# Altium schematic sheet sdd_radio_circuits.SchDoc(sdd_radio_circuits)
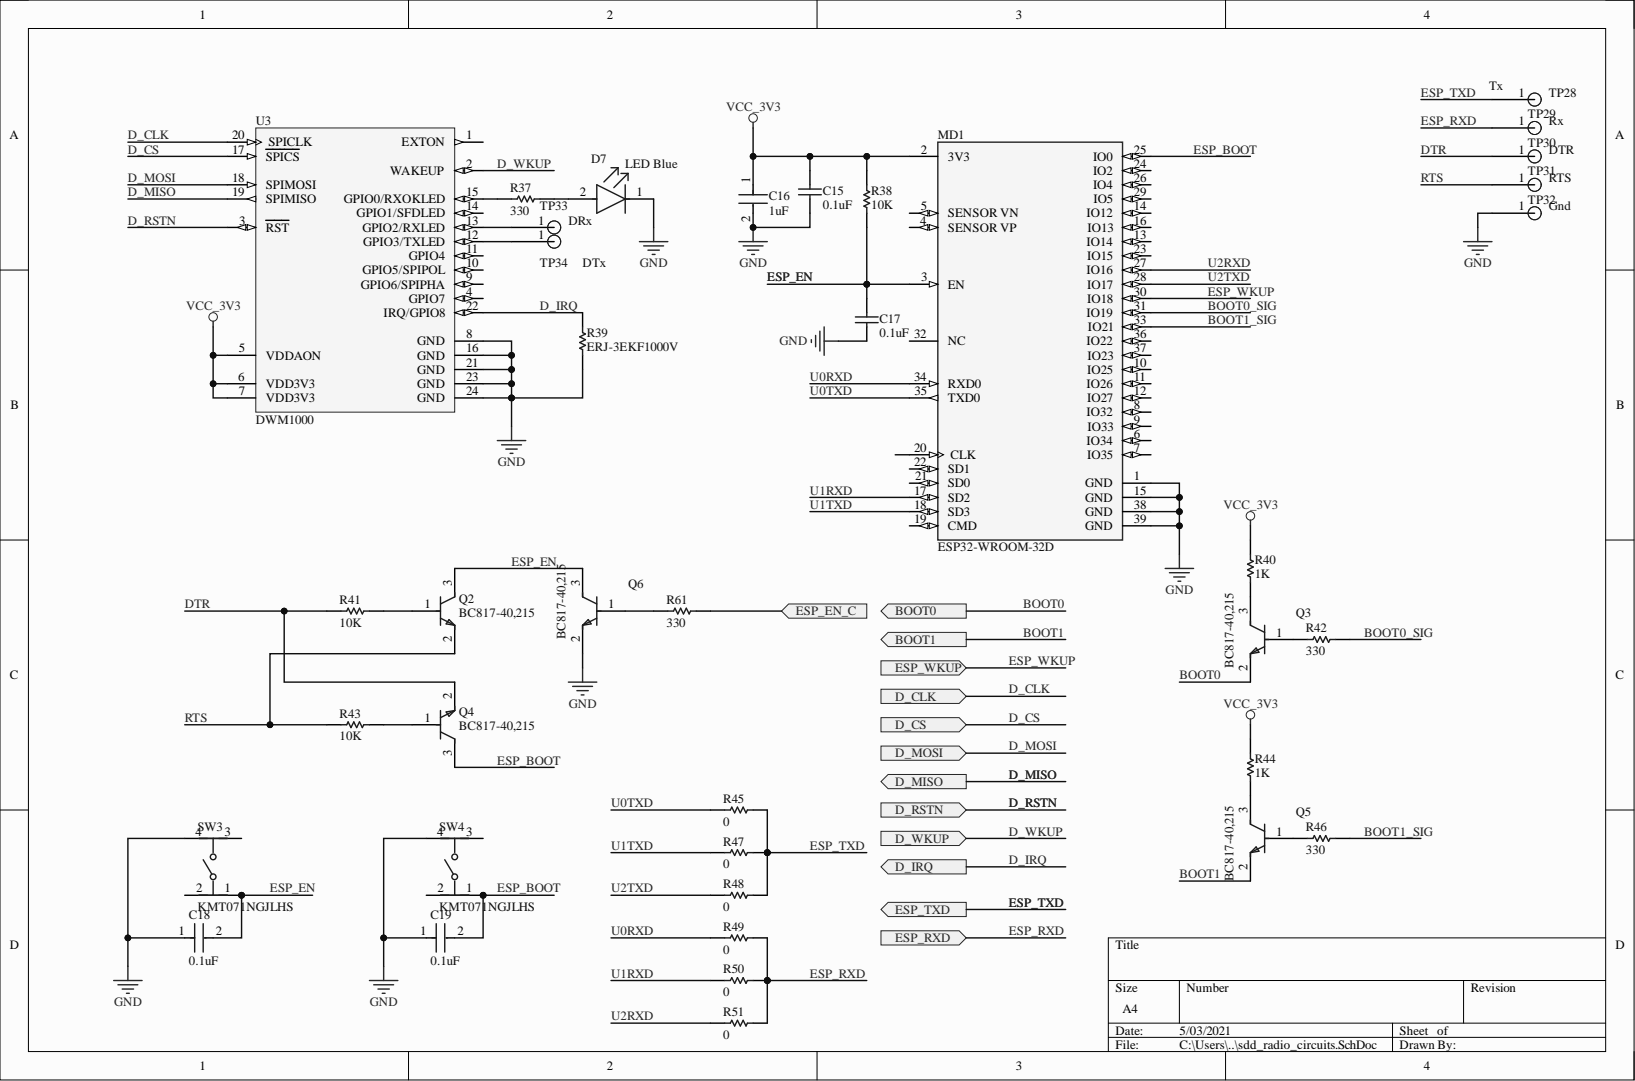
[diagram p0: sdd_radio_circuits.SchDoc]

## Parts
C15  0.1uF  [CAPC1608X90X35ML15T15]
C16  1uF  [FP-CL10B105KO8NNNC-MFG]
C17  0.1uF  [CAPC1608X90X35ML15T15]
C18  0.1uF  [FP-GRM022-0_02-IPC_A]
C19  0.1uF  [FP-GRM022-0_02-IPC_A]
D7  LED Blue  Color: Blue  [FP-QBLP595-IB-MFG]
MD1  ESP32-WROOM-32D  [FP-ESP32-WROOM-32D-MFG]
Q2  BC817-40,215  [FP-SOT23-MFG]
Q3  BC817-40,215  [FP-SOT23-MFG]
Q4  BC817-40,215  [FP-SOT23-MFG]
Q5  BC817-40,215  [FP-SOT23-MFG]
Q6  BC817-40,215  [FP-SOT23-MFG]
R37  330  [RESC1005X40X25LL05T10]
R38  10K  [RESC1608X55X30ML20T20]
R39  ERJ-3EKF1000V  [RESC1608X55X30LL15T15]
R40  1K  [RESC1005X40X25LL05T10]
R41  10K  [RESC1608X55X30ML20T20]
R42  330  [RESC1005X40X25LL05T10]
R43  10K  [RESC1608X55X30ML20T20]
R44  1K  [RESC1005X40X25LL05T10]
R45  0  [RESC1005X40X25NL05T10]
R46  330  [RESC1005X40X25LL05T10]
R47  0  [RESC1005X40X25NL05T10]
R48  0  [RESC1005X40X25NL05T10]
R49  0  [RESC1005X40X25NL05T10]
R50  0  [RESC1005X40X25NL05T10]
R51  0  [RESC1005X40X25NL05T10]
R61  330  [RESC1005X40X25LL05T10]
SW3  KMT071NGJLHS  [FP-KMT071NGJLHS-MFG]
SW4  KMT071NGJLHS  [FP-KMT071NGJLHS-MFG]
TP28  Tx  [Testpoint1]  (no BOM)
TP29  Rx  [Testpoint2]  (no BOM)
TP30  DTR  [Testpoint2]  (no BOM)
TP31  RTS  [Testpoint2]  (no BOM)
TP32  Gnd  [Testpoint2]  (no BOM)
TP33  DRx  [Testpoint2]  (no BOM)
TP34  DTx  [Testpoint2]  (no BOM)
U3  DWM1000  [DECW-DWM1000-24_V]

## Ports
BOOT0, BOOT1, D_CLK, D_CS, D_IRQ, D_MISO, D_MOSI, D_RSTN, D_WKUP, ESP_EN_C, ESP_RXD, ESP_TXD, ESP_WKUP

## Nets
GND: C15.2 C16.2 C17.1 C18.1 C19.1 D7.1 MD1.1(GND) MD1.15(GND) MD1.38(GND) MD1.39(GND) Q6.2 R39.1 SW3.4 SW4.4 TP32.1 U3.8(GND) U3.16(GND) U3.21(GND) U3.23(GND) U3.24(GND)
VCC_3V3: C15.1 C16.1 MD1.2(3V3) R38.2 R40.1 R44.1 U3.5(VDDAON) U3.6(VDD3V3) U3.7(VDD3V3)
BOOT0: Q3.2 ; port BOOT0
BOOT0_SIG: MD1.31(IO19) R42.2
BOOT1: Q5.2 ; port BOOT1
BOOT1_SIG: MD1.33(IO21) R46.2
D_CLK: U3.20(SPICLK) ; port D_CLK
D_CS: U3.17(SPICS) ; port D_CS
D_IRQ: R39.2 U3.22(IRQ/GPIO8) ; port D_IRQ
D_MISO: U3.19(SPIMISO) ; port D_MISO
D_MOSI: U3.18(SPIMOSI) ; port D_MOSI
D_RSTN: U3.3(RST) ; port D_RSTN
D_WKUP: U3.2(WAKEUP) ; port D_WKUP
DTR: Q4.2 R41.1 TP30.1
ESP_BOOT: C19.2 MD1.25(IO0) Q4.3 SW4.1
ESP_EN: C17.2 C18.2 MD1.3(EN) Q2.3 Q6.3 R38.1 SW3.1
ESP_EN_C: R61.2 ; port ESP_EN_C
ESP_RXD: R49.2 R50.2 R51.2 TP29.1 ; port ESP_RXD
ESP_TXD: R45.2 R47.2 R48.2 TP28.1 ; port ESP_TXD
ESP_WKUP: MD1.30(IO18) ; port ESP_WKUP
RTS: Q2.2 R43.1 TP31.1
U0RXD: MD1.34(RXD0) R49.1
U0TXD: MD1.35(TXD0) R45.1
U1RXD: MD1.17(SD2) R50.1
U1TXD: MD1.18(SD3) R47.1
U2RXD: MD1.27(IO16) R51.1
U2TXD: MD1.28(IO17) R48.1
NetD7_2: D7.2 R37.2
NetQ2_1: Q2.1 R41.2
NetQ3_1: Q3.1 R42.1
NetQ3_3: Q3.3 R40.2
NetQ4_1: Q4.1 R43.2
NetQ5_1: Q5.1 R46.1
NetQ5_3: Q5.3 R44.2
NetQ6_1: Q6.1 R61.1
NetR37_1: R37.1 U3.15(GPIO0/RXOKLED)
NetTP33_1: TP33.1 U3.13(GPIO2/RXLED)
NetTP34_1: TP34.1 U3.12(GPIO3/TXLED)

Not connected: MD1 4(SENSOR_VP) 5(SENSOR_VN) 6(IO34) 7(IO35) 8(IO32) 9(IO33) 10(IO25) 11(IO26) 12(IO27) 13(IO14) 14(IO12) 16(IO13) 19(CMD) 20(CLK) 21(SD0) 22(SD1) 23(IO15) 24(IO2) 26(IO4) 29(IO5) 32(NC) 36(IO22) 37(IO23); SW3 2 3; SW4 2 3; U3 1(EXTON) 4(GPIO7) 9(GPIO6/SPIPHA) 10(GPIO5/SPIPOL) 11(GPIO4) 14(GPIO1/SFDLED)

## Library data of the parts
C15 C17 = CMP-2006-04492-1: Manufacturer: AVX Interconnect / Elco; Part Number: 0603YC104K4T4A; Component Kind: Standard; Library Name: Altium Content Vault
C16 = CMP-2000-06093-2: CAP CER 1UF 16V X7R 0603; Manufacturer: Samsung Electro-Mechanics America, Inc; Manufacturer Part Number: CL10B105KO8NNNC; Material: Ceramic; Temperature Coefficient: 15%; Tolerance: 10%; Automotive: No; Capacitance: 1uF; Category: Cap; Component Kind: Standard; ComponentLink2Description: Datasheet; ComponentLink2URL: http://www.samsungsem.com/global/front/downloadcms.do?path=%2F%2Fglobal%2F%2Fsupport%2F%2Fproduct-search%2F%2Fmlcc%2F%2F__icsFiles%2F%2Fafieldfile%2F%2F2019%2F%2F06%2F%2F18&fileName=CL10B105KO8NNNC-19.pdf; Device Class L1: Passive Components; Device Class L2: Capacitors; Device Class L3: Ceramic Capacitors; Digikey Description: CAP CER 1UF 16V X7R 0603; Height: 0.9mm; Ipc Land Pattern Name: CAPC16080X80; Lead Free: Yes; Library Name: Altium Content Vault; Octopart: https://octopart.com/cl10b105ko8nnnc-samsung-19018973; Package: CL10B105KO8NNNC; RoHS: TRUE; Temperature: -55°C to +125°C; Temperature Characteristic: X7R; Temperature Range High: +125°C; Temperature Range Low: -55°C; Value: 1uF; Voltage Rating: 16V
C18 C19 = CMP-06035-048848-1: Chip Multilayer Ceramic Capacitors for General Purpose, 01005, 0.10uF, X5R, 15%, 20%, 6.3V; Capacitance: 100nF; Case Code (Imperial): 1005; Case Code (Metric): 1005; Case/Package: 0402; Component Kind: Standard; Dielectric: X5R; Height: 0.2mm; Lead Free: Lead Free; Lead Pitch: 0.232inch; Length: 0.016inch; Library Name: Altium Content Vault; Max Operating Temperature: 85°C; Min Operating Temperature: -55°C; Mount: Surface Mount; Package Quantity: 40000; Packaging: Tape and Reel; Termination: SMD/SMT; Thickness: 0.009inch; Tolerance: 20%; Voltage Rating: 6.3V; Voltage Rating (DC): 6.3V; Weight: 0.000212oz; Width: 0.2mm
D7 = CMP-113271-000002-1: LED BLUE CLEAR 0402 SMD; Dominant Wavelength: 465nm; Forward Voltage: 3.1V; Lens Color: Colorless; Lens Size: 0.5mm; Lens Style: Flat; Luminous Intensity: 85mcd; Test Current: 20mA; Viewing Angle: 140°; Case Code (Imperial): 0402; Case Code (Metric): 1005; Case/Package: 0402; Component Kind: Standard; Height: 0.6mm; Length: 1mm; Lens Transparency: Clear; Library Name: Altium Content Vault; Mount: Surface Mount; Packaging: Tape and Reel; Width: 0.5mm
MD1 = CMP-194065-000003-1: WIFI MODULE 32MBITS SPI FLASH; Manufacturer: Espressif Systems; Bandwidth: 72MHz; Data Rate: 150Mbits/s; Interface: I2C,SPI,UART,Ethernet,I2S,Other; Max Frequency: 2484MHz; Max Supply Voltage: 3.6V; Min Supply Voltage: 3V; Nominal Supply Current: 500mA; Number Of Adc Channels: 16; Number Of Gpio: 32; Sensitivity: -98dBm; Automotive: No; Category: UNK; Component Kind: Standard; ComponentLink2Description: Datasheet; ComponentLink2URL: https://www.espressif.com/sites/default/files/documentation/esp32-wroom-32d_esp32-wroom-32u_datasheet_en.pdf; Device Class L1: Integrated Circuits (ICs); Device Class L2: RF Semiconductors and Devices; Device Class L3: Transceivers; Digikey Description: WIFI MODULE 32MBITS SPI FLASH; Height: 3.2mm; Lead Free: Yes; Library Name: Altium Content Vault; Manufacturer Part Number: ESP32-WROOM-32D; Mouser Description: Wi-Fi/BT BLE Module ESP32-D0WD, SPI Flash, UART, P; Octopart: https://octopart.com/esp32-wroom-32d-espressif+systems-91040050; Package: ESP32-WROOM-32D; RoHS: TRUE; Temperature: -40°C to +85°C; Temperature Range High: +85°C; Temperature Range Low: -40°C
Q2-Q6 = CMP-14406-000007-1: TRANS NPN 45V 0.5A SOT23; Manufacturer: Nexperia; Automotive Grade: Grade 0; Collector Base Voltage: 50V; Collector Emitter Saturation Voltage: 700mV; Emitter Base Voltage: 5V; Hfe Max: 600; Hfe Min: 250; Hfe Testic: 100mA; Hfe Testvce: 1V; Max Collector Current: 500mA; Max Junction Temp: +150°C; Number Of Elements: 1; Power Dissipation: 250mW; Rthja Max: 500K/W; Unity Gain Freq: 100MHz; Ambient Temperature Range High: +150°C; Ambient Temperature Range Low: -65°C; Automotive: Yes; Category: Trans; Collector Emitter Breakdown Voltage: 45V; Collector Emitter Voltage: 45V; Component Kind: Standard; ComponentLink2Description: Datasheet; ComponentLink2URL: https://assets.nexperia.com/documents/data-sheet/BC817_SER.pdf; Device Class L1: Discrete Semiconductors; Device Class L2: Transistors; Device Class L3: BJTs; Digikey Description: TRANS NPN 45V 0.5A SOT23; Footprint Url: https://assets.nexperia.com/documents/outline-drawing/SOT23.pdf; Height: 1.1mm; Ipc Land Pattern Name: SOT95P230X100-3; Lead Free: Yes; Library Name: Altium Content Vault; Manufacturer Part Number: BC817-40,215; Mouser Description: BC817 Series 45 V 500 mA 250 mW SMT NPN General-Purpose Transistor - SOT-23-; Number Of Npn: 1; Octopart: https://octopart.com/bc817-40%2C215-nexperia-78739081; Package: SOT23; RoHS: TRUE; Temperature: to +150°C; Temperature Range High: +150°C
R37 R42 R46 R61 = CMP-2002-07613-1: Manufacturer: Yageo / Phycomp; Part Number: RC0402FR-07330RL; Component Kind: Standard; Library Name: Altium Content Vault
R38 R41 R43 = CMP-2000-00874-1: Manufacturer: Panasonic; Part Number: ERA-3AEB103V; Component Kind: Standard; Library Name: Altium Content Vault
R39 = CMP-2000-00179-1: Manufacturer: Panasonic; Component Kind: Standard; Library Name: Altium Content Vault; Part Number: ERJ-3EKF1000V
R40 R44 = CMP-2002-03045-1: Manufacturer: Vishay Dale; Part Number: CRCW04021K00FKEDHP; Component Kind: Standard; Library Name: Altium Content Vault
R45 R47-R51 = CMP-1011-00001-2: Manufacturer: Yageo / Phycomp; Part Number: RC0402JR-070RL; Component Kind: Standard; Library Name: Altium Content Vault
SW3 SW4 = CMP-10040-000017-1: SWITCH TACTILE SPST-NO 0.05A 32V; Actuator Type: Standard; Current Rating: 50mA; Illumination Type: Non-Illuminated; Mechanical Life: 300000Cycles; Operating Force: 2.3N; Power Rating: 500mW; Ratings: IP68; Switch Function: Off-Mom; Switch Travel: 0.15mm; Throw Configuration: SPST; Case/Package: SMD/SMT; Component Kind: Standard; Contact Material: Silver; Contact Plating: Silver; Depth: 3.4mm; Lead Free: Lead Free; Length: 2.6mm; Library Name: Altium Content Vault; Max Current Rating: 50mA; Max Operating Temperature: 85°C; Max Voltage Rating (DC): 32V; Min Operating Temperature: -40°C; Mount: Surface Mount; Orientation: Straight; Package Quantity: 5000; Packaging: Tape and Reel; Radiation Hardening: No; REACH SVHC: No SVHC; Termination: J-Lead; Voltage Rating (DC): 32V
TP28 = Testpoint1: Library Name: TestPoint.IntLib
TP29-TP34 = Testpoint2: Library Name: TestPoint_Round.IntLib
U3 = CMP-2000-05094-1: DW M1000 IEEE 802.15.4 -2011 UWB Transceiver Module; Manufacturer: Decawave; Antenna Type: Integrated,Chip; Current - Receiving: 64mA; Current - Transmitting: 31mA; Data Rate: 6.8Mbps; Design Resources: DevelopmentToolSelector; Featured Product: ScenSorDWM1000Module; Frequency: 3.5GHz~6.5GHz; Modulation: BPM,BPSK; Other Names: 1479-1002-2RUPF-G001A; Protocol: IR-UWB; Serial Interfaces: SPI; Voltage - Supply: 2.8V~3.6V; Category: RF/IFandRFID; Component Kind: Standard; Datasheet URL: http://www.decawave.com/sites/default/files/resources/dwm1000-datasheet-v1.3.pdf; Datasheet Version: Rev. V1.0, 12/2015; Family: RFTransceiverModules; Library Name: Altium Content Vault; Manufacturer URL: http://www.decawave.com/; Memory Size: -; Mounting Type: SurfaceMount; Online Catalog: ScenSorDWM1000Module; Operating Temperature: -40degC~85degC; Package / Case: Module; Packaging: Tape&Reel(TR); Power - Output: -; RF Family/Standard: 802.15.4; Sensitivity: -; Series: -; Standard Package: 500
